# Altium schematic sheet RS485&CAN.SchDoc
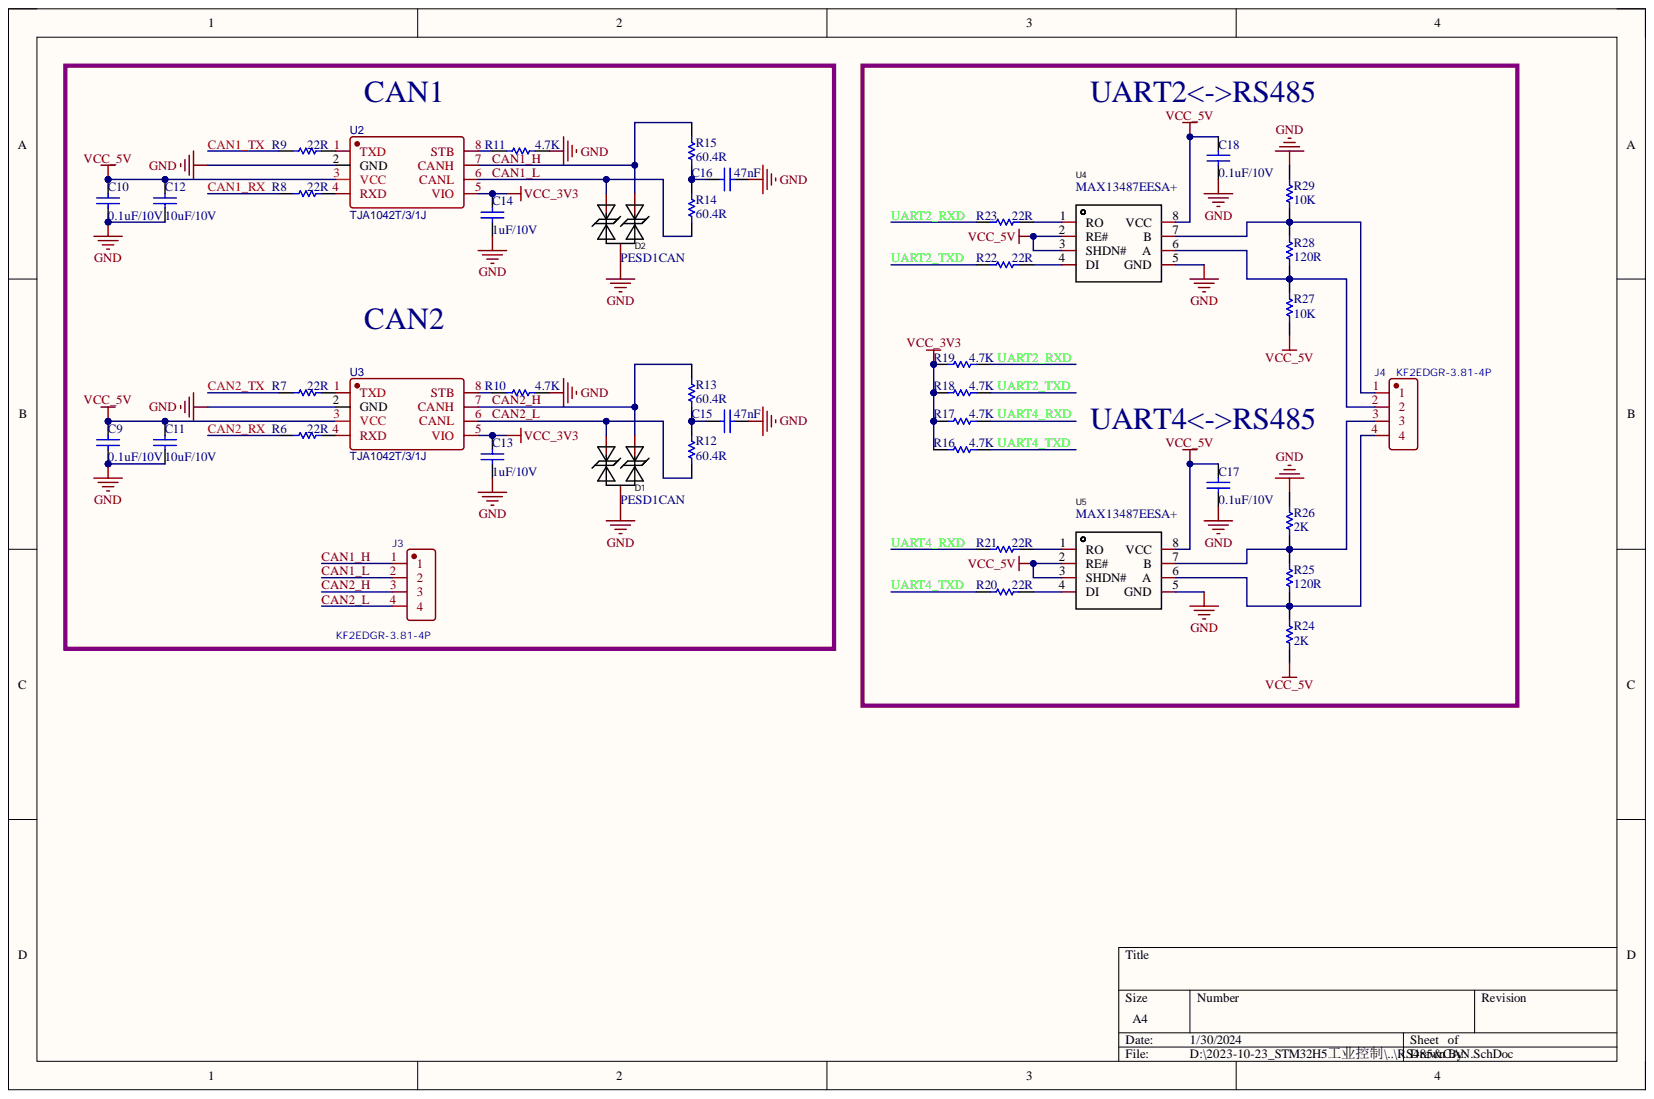
[diagram p0: RS485&CAN.SchDoc]

## Parts
C9  0.1uF/10V  [0402]
C10  0.1uF/10V  [0402]
C11  10uF/10V  [0402]
C12  10uF/10V  [0402]
C13  1uF/10V  [0402]
C14  1uF/10V  [0402]
C15  47nF  [0402]
C16  47nF  [0402]
C17  0.1uF/10V  [0402]
C18  0.1uF/10V  [0402]
D1  PESD1CAN  [SOT-23-3_L2.9-W1.6-P1.90-LS2.8-BR]
D2  PESD1CAN  [SOT-23-3_L2.9-W1.6-P1.90-LS2.8-BR]
J3  KF2EDGR-3.81-4P  [CONN-TH_4P-P3.81_KF2EDGR-3.81-4P]
J4  KF2EDGR-3.81-4P  [CONN-TH_4P-P3.81_KF2EDGR-3.81-4P]
R6  22R  [0402]
R7  22R  [0402]
R8  22R  [0402]
R9  22R  [0402]
R10  4.7K  [0402]
R11  4.7K  [0402]
R12  60.4R  [0402]
R13  60.4R  [0402]
R14  60.4R  [0402]
R15  60.4R  [0402]
R16  4.7K  [0402]
R17  4.7K  [0402]
R18  4.7K  [0402]
R19  4.7K  [0402]
R20  22R  [0402]
R21  22R  [0402]
R22  22R  [0402]
R23  22R  [0402]
R24  2K  [0402]
R25  120R  [0603]
R26  2K  [0402]
R27  10K  [0402]
R28  120R  [0603]
R29  10K  [0402]
U2  TJA1042T/3/1J  [SOIC-8_L4.9-W3.9-P1.27-LS6.0-BL]
U3  TJA1042T/3/1J  [SOIC-8_L4.9-W3.9-P1.27-LS6.0-BL]
U4  MAX13487EESA+  [SOIC-8_L4.9-W3.9-P1.27-LS6.0-BL]
U5  MAX13487EESA+  [SOIC-8_L4.9-W3.9-P1.27-LS6.0-BL]

## Nets
GND: C9.2 C10.2 C11.2 C12.2 C13.1 C14.1 C15.2 C16.2 C17.1 C18.1 D1.3 D2.3 R10.1 R11.1 R26.2 R29.2 U2.2(GND) U3.2(GND) U4.5(GND) U5.5(GND)
VCC_3V3: C13.2 C14.2 R16.2 R17.2 R18.2 R19.2 U2.5(VIO) U3.5(VIO)
VCC_5V: C9.1 C10.1 C11.1 C12.1 C17.2 C18.2 R24.1 R27.1 U2.3(VCC) U3.3(VCC) U4.2(RE#) U4.3(SHDN#) U4.8(VCC) U5.2(RE#) U5.3(SHDN#) U5.8(VCC)
CAN1_H: D2.1 J3.1 R15.2 U2.7(CANH)
CAN1_L: D2.2 J3.2 R14.1 U2.6(CANL)
CAN1_RX: R8.2
CAN1_TX: R9.2
CAN2_H: D1.1 J3.3 R13.2 U3.7(CANH)
CAN2_L: D1.2 J3.4 R12.1 U3.6(CANL)
CAN2_RX: R6.2
CAN2_TX: R7.2
UART2_RXD: R19.1 R23.1
UART2_TXD: R18.1 R22.1
UART4_RXD: R17.1 R21.1
UART4_TXD: R16.1 R20.1
NetC15_1: C15.1 R12.2 R13.1
NetC16_1: C16.1 R14.2 R15.1
NetJ4_1: J4.1 R28.2 R29.1 U4.7(B)
NetJ4_2: J4.2 R27.2 R28.1 U4.6(A)
NetJ4_3: J4.3 R25.2 R26.1 U5.7(B)
NetJ4_4: J4.4 R24.2 R25.1 U5.6(A)
NetR6_1: R6.1 U3.4(RXD)
NetR7_1: R7.1 U3.1(TXD)
NetR8_1: R8.1 U2.4(RXD)
NetR9_1: R9.1 U2.1(TXD)
NetR10_2: R10.2 U3.8(STB)
NetR11_2: R11.2 U2.8(STB)
NetR20_2: R20.2 U5.4(DI)
NetR21_2: R21.2 U5.1(RO)
NetR22_2: R22.2 U4.4(DI)
NetR23_2: R23.2 U4.1(RO)

## Library data of the parts
C9-C18 = Cap: Capacitor; PartNumber: CAP100NF; Component Kind: Standard; LatestRevisionDate: 17-Jul-2002; LatestRevisionNote: Re-released for DXP Platform.; Library Name: Miscellaneous Devices.IntLib; PackageReference: 0402; Published: 8-Jun-2000; Signal Integrity: Cap; Simulation: CAP; Publisher: Altium Limited
  C9 C10 C17 C18: Value: 0.1uF/10V
  C11 C12: Value: 10uF/10V
  C13 C14: Value: 1uF/10V
  C15 C16: Value: 47nF
D1 D2 = PESD1CAN_C343993: Add into BOM: yes; Breakdown Voltage (VBR): 26.7V; Clamping Voltage@Ipp: 48V; Peak Pulse Current(Ipp)@8/20us: 6A; Reverse Stand-Off Voltage (Vrwm): 24V; Component Kind: Standard; Convert to PCB: yes; Datasheet: https://atta.szlcsc.com/upload/public/pdf/source/20181211/C343993_AF595724ADB82F42A1C256C97FD7AD6B.pdf; Device: PESD1CAN_C343993; JLCPCB Part Class: Extended Part; LCSC Part Name: PESD1CAN; Manufacturer Part: PESD1CAN; Name: PESD1CAN; PCB3D: SOT-23-3L_L2.9-W1.6-H1.1-LS2.8-P0.95; Supplier: LCSC; Supplier Footprint: SOT-23; Supplier Part: C343993; Symbol: PESD1CAN_C343993
R6-R19 R24-R29 = Res1: Resistor; PartNumber: RES22R; Component Kind: Standard; LatestRevisionDate: 17-Jul-2002; LatestRevisionNote: Re-released for DXP Platform.; Library Name: Miscellaneous Devices.IntLib; PackageReference: 0402; Published: 8-Jun-2000; Signal Integrity: Res; Simulation: RESISTOR; Publisher: Altium Limited
  R6-R9: Value: 22R
  R10 R11 R16-R19: Value: 4.7K
  R12-R15: Value: 60.4R
  R24 R26: Value: 2K
  R25 R28: Value: 120R
  R27 R29: Value: 10K
R20-R23 = Res1: Resistor; PartNumber: RES2.2K; Component Kind: Standard; LatestRevisionDate: 17-Jul-2002; LatestRevisionNote: Re-released for DXP Platform.; Library Name: Miscellaneous Devices.IntLib; PackageReference: 0402; Published: 8-Jun-2000; Signal Integrity: Res; Simulation: RESISTOR; Value: 22R; Publisher: Altium Limited
U2 U3 = TJA1042T/3/1J: BOM_Manufacturer: NXP Semicon; Contributor: LCSC; BOM_JLCPCB Part Class: Extended Part; BOM_Manufacturer Part: TJA1042T/3/1J; BOM_Supplier: LCSC; BOM_Supplier Part: C132227; Component Kind: Standard; Library Name: HM2.SchLib; spicePre: U; spiceSymbolName: TJA1042T/3/1J
U4 U5 = MAX13487EESA+: Add into BOM: yes; Data Rate: 500Kbps; Driver/receiver: 1/1; Supply Voltage: 4.75V~5.25V; Component Kind: Standard; Convert to PCB: yes; Datasheet: https://atta.szlcsc.com/upload/public/pdf/source/20171204/C143342_15123772087731162612.pdf; Device: MAX13487EESA+; JLCPCB Part Class: Extended Part; Manufacturer Part: MAX13487EESA+; Name: MAX13487EESA+; PCB3D: SOIC-8_L4.9-W3.9-H1.7-LS6.0-P1.27; Supplier: LCSC; Supplier Footprint: SOIC-8; Supplier Part: C143342; Symbol: MAX13487EESA+
J3 J4 = KF2EDGR-3.81-4P: Component Kind: Standard; JLC NO: C441184
